# Altium schematic sheet circuit.SchDoc
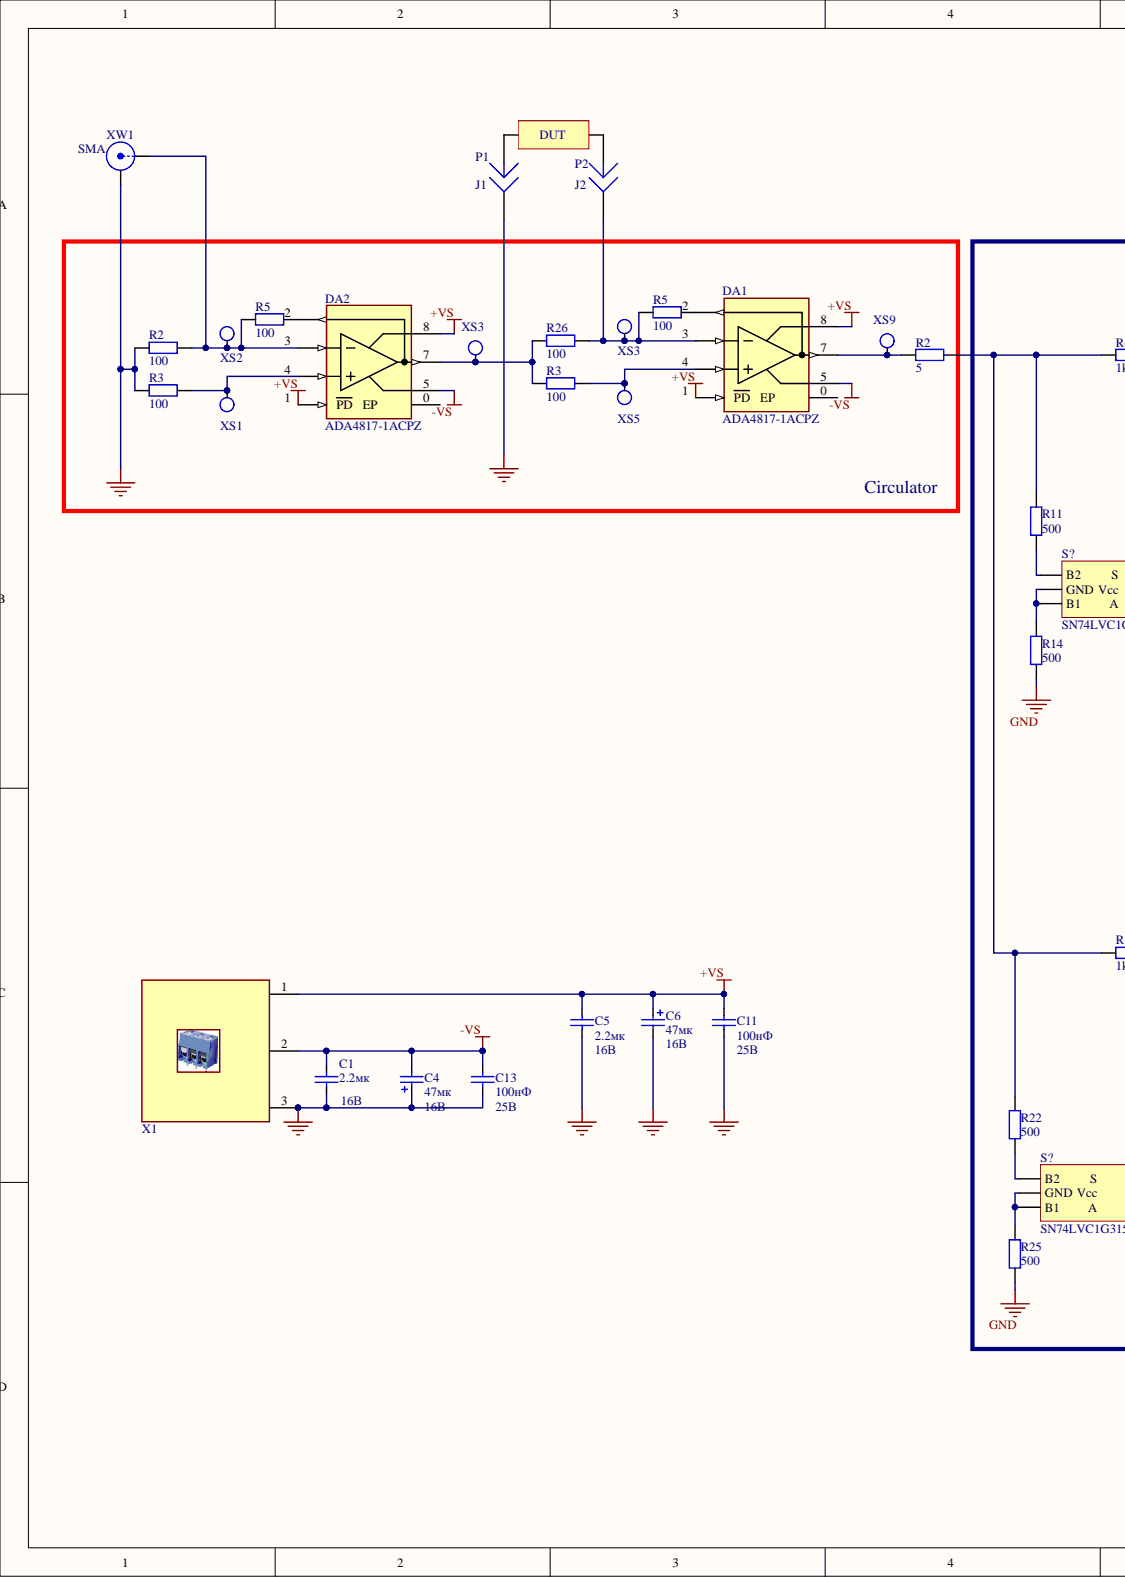
[diagram p0: circuit.SchDoc, left]
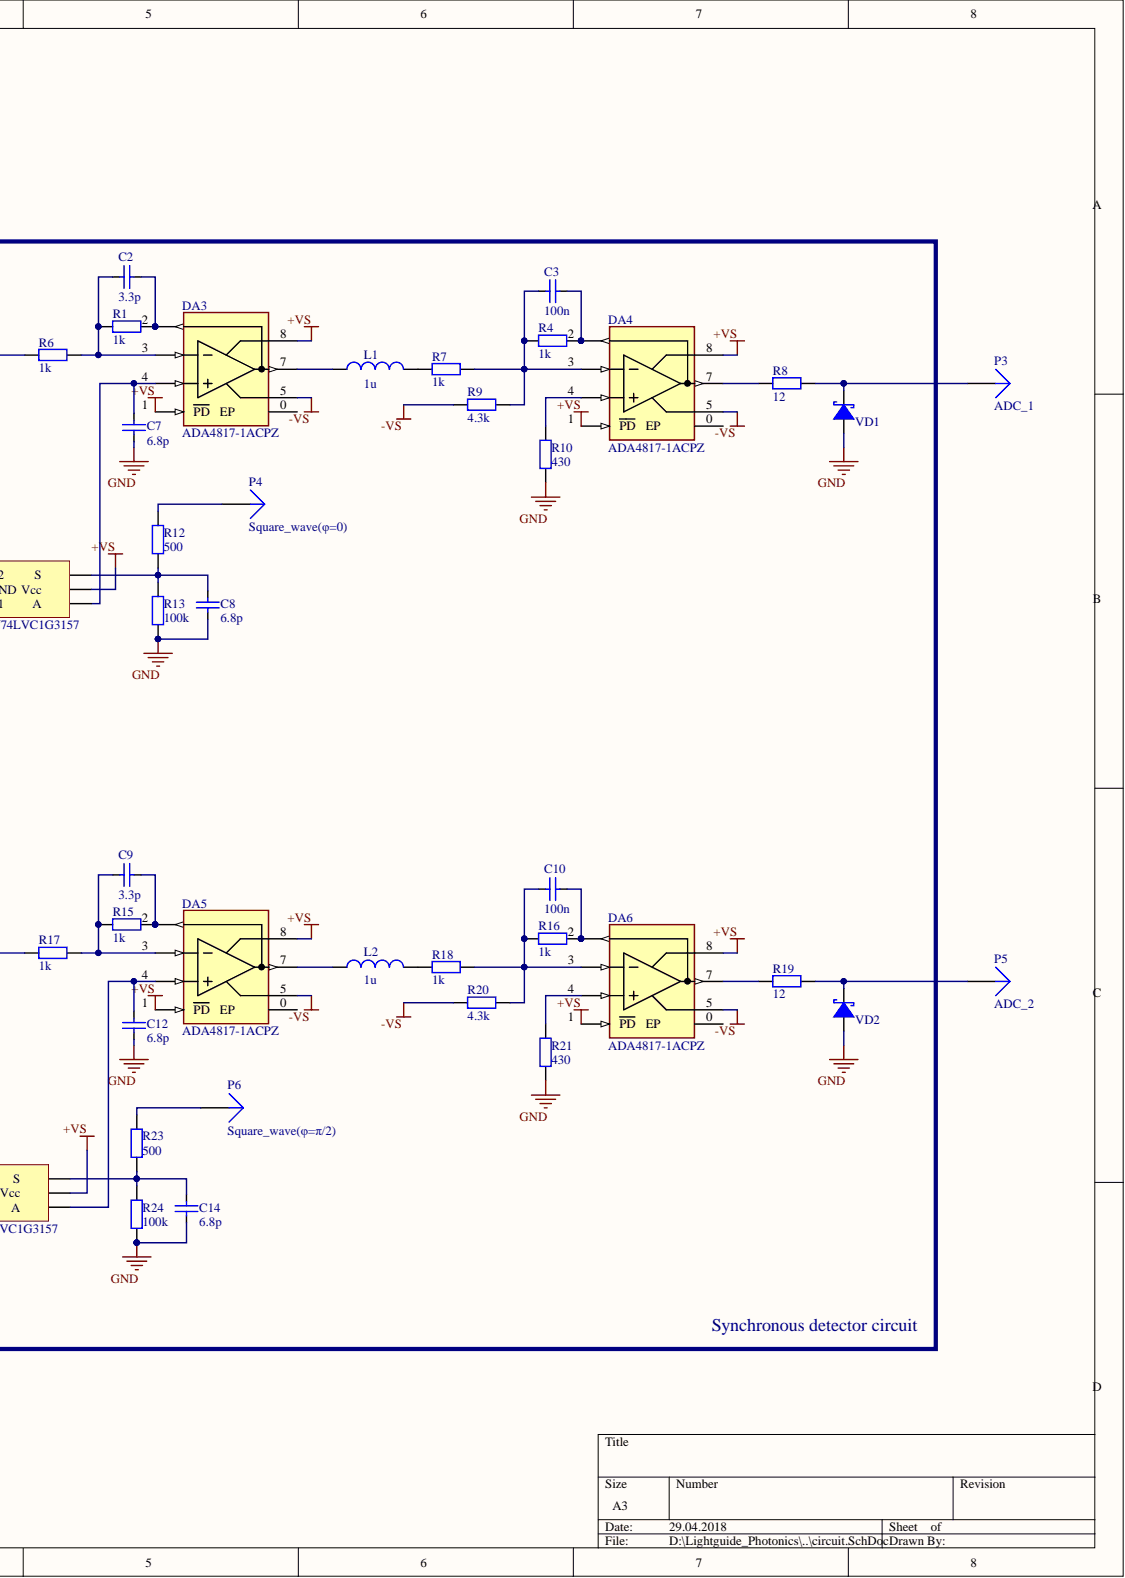
[diagram p1: circuit.SchDoc, right]

## Parts
*  DUT
C1  value 2.2мк  Voltage: 16В  [C0603]  (hidden comment: C1210C476M9RAC)
C2  value 3.3p  [C0603]  (hidden comment: 0603YC272JAT2A)
C3  value 100n  [C0603]  (hidden comment: 0603YC272JAT2A)
C4  value 47мк  Voltage: 16В  [TAN_D]  (hidden comment: TPSD227M010R0050)
C5  value 2.2мк  Voltage: 16В  [C0603]  (hidden comment: C1210C476M9RAC)
C6  value 47мк  Voltage: 16В  [TAN_D]  (hidden comment: TPSD227M010R0050)
C7  value 6.8p  [C0603]  (hidden comment: 0603YC272JAT2A)
C8  value 6.8p  [C0603]  (hidden comment: 0603YC272JAT2A)
C9  value 3.3p  [C0603]  (hidden comment: 0603YC272JAT2A)
C10  value 100n  [C0603]  (hidden comment: 0603YC272JAT2A)
C11  value 100нФ  Voltage: 25В  [C0603]  (hidden comment: 06033A270JAT2A)
C12  value 6.8p  [C0603]  (hidden comment: 0603YC272JAT2A)
C13  value 100нФ  Voltage: 25В  [C0603]  (hidden comment: 06033A270JAT2A)
C14  value 6.8p  [C0603]  (hidden comment: 0603YC272JAT2A)
DA1  ADA4817-1ACPZ  [LFCSPVD-8]
DA2  ADA4817-1ACPZ  [LFCSPVD-8]
DA3  ADA4817-1ACPZ  [LFCSPVD-8]
DA4  ADA4817-1ACPZ  [LFCSPVD-8]
DA5  ADA4817-1ACPZ  [LFCSPVD-8]
DA6  ADA4817-1ACPZ  [LFCSPVD-8]
J1  Socket  [PIN1]  (value not drawn)
J2  Socket  [PIN1]  (value not drawn)
L1  value 1u  (hidden comment: !IND_NONE)
L2  value 1u  (hidden comment: !IND_NONE)
P1  Plug  [PIN1]  (value not drawn)
P2  Plug  [PIN1]  (value not drawn)
P3  ADC_1  [PIN1]
P4  Square_wave(φ=0)  [PIN1]
P5  ADC_2  [PIN1]
P6  Square_wave(φ=π/2)  [PIN1]
R1  value 1k  [R0603]  (hidden comment: CR0603-FX-1000ELF)
R2  value 5  [R0603]  (hidden comment: CR0603-FX-1000ELF)
R2  value 100  [R0603]  (hidden comment: CR0603-FX-1000ELF)
R3  value 100  [R0603]  (hidden comment: CR0603-FX-1000ELF)
R3  value 100  [R0603]  (hidden comment: CR0603-FX-1000ELF)
R4  value 1k  [R0603]  (hidden comment: CR0603-FX-1000ELF)
R5  value 100  [R0603]  (hidden comment: CR0603-FX-1000ELF)
R5  value 100  [R0603]  (hidden comment: CR0603-FX-1000ELF)
R6  value 1k  [R0603]  (hidden comment: CR0603-FX-1000ELF)
R7  value 1k  [R0603]  (hidden comment: CR0603-FX-1000ELF)
R8  value 12  [R0603]  (hidden comment: CR0603-FX-1000ELF)
R9  value 4.3k  [R0603]  (hidden comment: CR0603-FX-1000ELF)
R10  value 430  [R0603]  (hidden comment: CR0603-FX-1000ELF)
R11  value 500  [R0603]  (hidden comment: CR0603-FX-1000ELF)
R12  value 500  [R0603]  (hidden comment: CR0603-FX-1000ELF)
R13  value 100k  [R0603]  (hidden comment: CR0603-FX-1000ELF)
R14  value 500  [R0603]  (hidden comment: CR0603-FX-1000ELF)
R15  value 1k  [R0603]  (hidden comment: CR0603-FX-1000ELF)
R16  value 1k  [R0603]  (hidden comment: CR0603-FX-1000ELF)
R17  value 1k  [R0603]  (hidden comment: CR0603-FX-1000ELF)
R18  value 1k  [R0603]  (hidden comment: CR0603-FX-1000ELF)
R19  value 12  [R0603]  (hidden comment: CR0603-FX-1000ELF)
R20  value 4.3k  [R0603]  (hidden comment: CR0603-FX-1000ELF)
R21  value 430  [R0603]  (hidden comment: CR0603-FX-1000ELF)
R22  value 500  [R0603]  (hidden comment: CR0603-FX-1000ELF)
R23  value 500  [R0603]  (hidden comment: CR0603-FX-1000ELF)
R24  value 100k  [R0603]  (hidden comment: CR0603-FX-1000ELF)
R25  value 500  [R0603]  (hidden comment: CR0603-FX-1000ELF)
R26  value 100  [R0603]  (hidden comment: CR0603-FX-1000ELF)
S?  SN74LVC1G3157
S?  SN74LVC1G3157
VD1  !DIODE_SCH  (value not drawn)
VD2  !DIODE_SCH  (value not drawn)
X1  DG300-5.0-03P  [DG300-0.5-03p]  (value not drawn)
XS1  TESTPOINT  [TESTPOINT_V2]  (value not drawn)
XS2  TESTPOINT  [TESTPOINT_V2]  (value not drawn)
XS3  TESTPOINT  [TESTPOINT_V2]  (value not drawn)
XS3  TESTPOINT  [TESTPOINT_V2]  (value not drawn)
XS5  TESTPOINT  [TESTPOINT_V2]  (value not drawn)
XS9  TESTPOINT  [TESTPOINT_V2]  (value not drawn)
XW1  SMA  [BNC_RA CON]

## Nets
+VS: C5.2 C6.1 C11.1 DA1.1(PD) DA1.8 DA2.1(PD) DA2.8 DA3.1(PD) DA3.8 DA4.1(PD) DA4.8 DA5.1(PD) DA5.8 DA6.1(PD) DA6.8 S?.15 X1.1
-VS: C1.2 C4.2 C13.1 DA1.5 DA2.5 DA3.5 DA4.5 DA5.5 DA6.5 R9.1 R20.1 X1.2
GND: C1.1 C4.1 C7.1 C8.2 C12.1 C13.2 C14.2 R10.2 R13.2 R14.2 R21.2 R24.2 R25.2 VD1.1 VD2.1 X1.3
: C5.1 C6.2 C11.2 J1.1 R2.1 R3.1 XW1.2
Net*_1: *.1 P1.1
Net*_2: *.2 P2.1
NetC2_1: C2.1 DA3.3 R1.1 R6.2
NetC2_2: C2.2 DA3.2 R1.2
NetC3_1: C3.1 DA4.3 R4.1 R7.2 R9.2
NetC3_2: C3.2 DA4.2 R4.2
NetC7_2: C7.2 DA3.4 S?.16
NetC8_1: C8.1 R12.2 R13.1 S?.14
NetC9_1: C9.1 DA5.3 R15.1 R17.2
NetC9_2: C9.2 DA5.2 R15.2
NetC10_1: C10.1 DA6.3 R16.1 R18.2 R20.2
NetC10_2: C10.2 DA6.2 R16.2
NetC12_2: C12.2 DA5.4 S?.16
NetC14_1: C14.1 R23.2 R24.1 S?.14
NetDA1_2: DA1.2 R5.2
NetDA1_3: DA1.3 J2.1 R5.1 R26.2 XS3.0
NetDA1_4: DA1.4 R3.2 XS5.0
NetDA1_7: DA1.7 R2.1 XS9.0
NetDA2_2: DA2.2 R5.2
NetDA2_3: DA2.3 R2.2 R5.1 XS2.0 XW1.1
NetDA2_4: DA2.4 R3.2 XS1.0
NetDA2_7: DA2.7 R3.1 R26.1 XS3.0
NetDA3_7: DA3.7 L1.1
NetDA4_4: DA4.4 R10.1
NetDA4_7: DA4.7 R8.1
NetDA5_7: DA5.7 L2.1
NetDA6_4: DA6.4 R21.1
NetDA6_7: DA6.7 R19.1
NetL1_2: L1.2 R7.1
NetL2_2: L2.2 R18.1
NetP3_1: P3.1 R8.2 VD1.2
NetP4_1: P4.1 R12.1
NetP5_1: P5.1 R19.2 VD2.2
NetP6_1: P6.1 R23.1
NetR2_2: R2.2 R6.1 R11.1 R17.1 R22.1
NetR11_2: R11.2 S?.11
NetR14_1: R14.1 S?.12 S?.13
NetR22_2: R22.2 S?.11
NetR25_1: R25.1 S?.12 S?.13

Not connected: DA1 0; DA2 0; DA3 0; DA4 0; DA5 0; DA6 0

## Library data of the parts
C1 C5 = C1210C476M9RAC: Capacitor Non-Polarized; Manufacturer: Kemet; Dielectric Type/TC: X7R; Tolerance: 20%; Type: MLCC; Case: 1210; Component Kind: Standard; Last Modified: 03.06.2014; Manufacturer ID: C1210C476M9RAC; Temperature Max: 125; Temperature Min: -55; Creator: Volkovskiy; Library Name: PAS_CAP.SchLib
C2 C3 C7-C10 C12 C14 = 0603YC272JAT2A: Capacitor Non-Polarized; Manufacturer: AVX; Dielectric Type/TC: X7R; Tolerance: 10%; Type: MLCC; Voltage: 16В; Case: 0603; Component Kind: Standard; Last Modified: 17.06.2014; Manufacturer ID: 0603YC272JAT2A; Temperature Max: 125; Temperature Min: -55; Creator: Volkovskiy; Library Name: PAS_CAP.SchLib
C4 C6 = TPSD227M010R0050: Capacitor Polarized; Manufacturer: AVX; Supervisor: Volkovskiy; Tolerance: 20%; Type: Tantalum; Case: D; Component Kind: Standard; Last Modified: 04.06.2014; Manufacturer ID: TPSD227M010R0050; Temperature Max: 125; Temperature Min: -55; Creator: Kikilich; Library Name: PAS_CAP.SchLib
C11 C13 = 06033A270JAT2A: Capacitor Non-Polarized; Manufacturer: AVX; Catalog Code: 62880000000484; Catalog ID: К2.72; Dielectric Type/TC: NP0; Tolerance: 5%; Type: MLCC; Case: 0603; Component Kind: Standard; Last Modified: 23.09.2014; Manufacturer ID: 06033A270JAT2A; Temperature Max: 125; Temperature Min: -55; Creator: Volkovskiy; Library Name: PAS_CAP.SchLib
DA1-DA6 = ADA4817-1ACPZ: Operatonal Amplifier; Manufacturer: Analog Devices; Catalog Code: 63391000001238; Catalog ID: К3.2106; Case: LFCSPVD-8; Component Kind: Standard; Last Modified: 11.06.2014; Library Name: IC_OPA.SchLib; Manufacturer ID: ADA4817-1ACPZ; Temperature Max: 105; Temperature Min: -40; Creator: Volkovskiy
L1 L2 = !IND_NONE: Coreless Inductor; Component Kind: Standard; Library Name: PAS_IND.SchLib
P3-P6 = Plug: Component Kind: Standard; Description: Plug; LatestRevisionDate: 17-Jul-2002; LatestRevisionNote: Re-released for DXP Platform.; Library Name: Miscellaneous Connectors.IntLib; Signal Integrity: Connector; Publisher: Altium Limited
R1 R2 R2 R3 R3-R5 R5-R26 = CR0603-FX-1000ELF: Resistor Standart; Manufacturer: Bourns; TCR: 100ppm; Tolerance: 1%; Case: 0603; Component Kind: Standard; Last Modified: 20.06.2014; Manufacturer ID: CR0603-FX-1000ELF; Temperature Max: 155; Temperature Min: -55; Type: Thick Film; Creator: Volkovskiy; Library Name: PAS_RES.SchLib
VD1 VD2 = !DIODE_SCH: Diode Schottky; Component Kind: Standard; Library Name: SEM_DIODES.SchLib
X1 = DG300-5.0-03P: Connector; Manufacturer: DEGSON; Component Kind: Standard; Last Modified: 16.06.2014; Library Name: MISC_CONNECTORS.SchLib; Manufacturer ID: DG300-5.0-03P; Temperature Max: 120; Temperature Min: -30; Creator: Volkovskiy
XS1-XS3 XS3 XS5 XS9 = TESTPOINT: Simple Round Testpoint; Component Kind: Standard; Last Modified: 17.06.2014; Library Name: MISC_MISCELLANEOUS.SchLib; Temperature Max: 280; Temperature Min: -100; Creator: Volkovskiy
XW1 = BNC: BNC Elbow Connector; Component Kind: Standard; LatestRevisionDate: 17-Jul-2002; LatestRevisionNote: Re-released for DXP Platform.; Library Name: MISC_CONNECTORS.SchLib; Publisher: Altium Limited
* = DUT: Component Kind: Standard; Library Name: DEFAULT.SchLib
J1 J2 = Socket: Component Kind: Standard; Description: Socket; LatestRevisionDate: 17-Jul-2002; LatestRevisionNote: Re-released for DXP Platform.; Library Name: Miscellaneous Connectors.IntLib; Signal Integrity: Connector; Publisher: Altium Limited
P1 P2 = Plug: Component Kind: Standard; Description: Plug; LatestRevisionDate: 17-Jul-2002; LatestRevisionNote: Re-released for DXP Platform.; Library Name: Miscellaneous Connectors.IntLib; Signal Integrity: Connector; Publisher: Altium Limited
S? S? = SN74LVC1G3157: Component Kind: Standard; Library Name: DEFAULT.SchLib
